# Altium schematic sheet JacdacHighPower 31.SchDoc
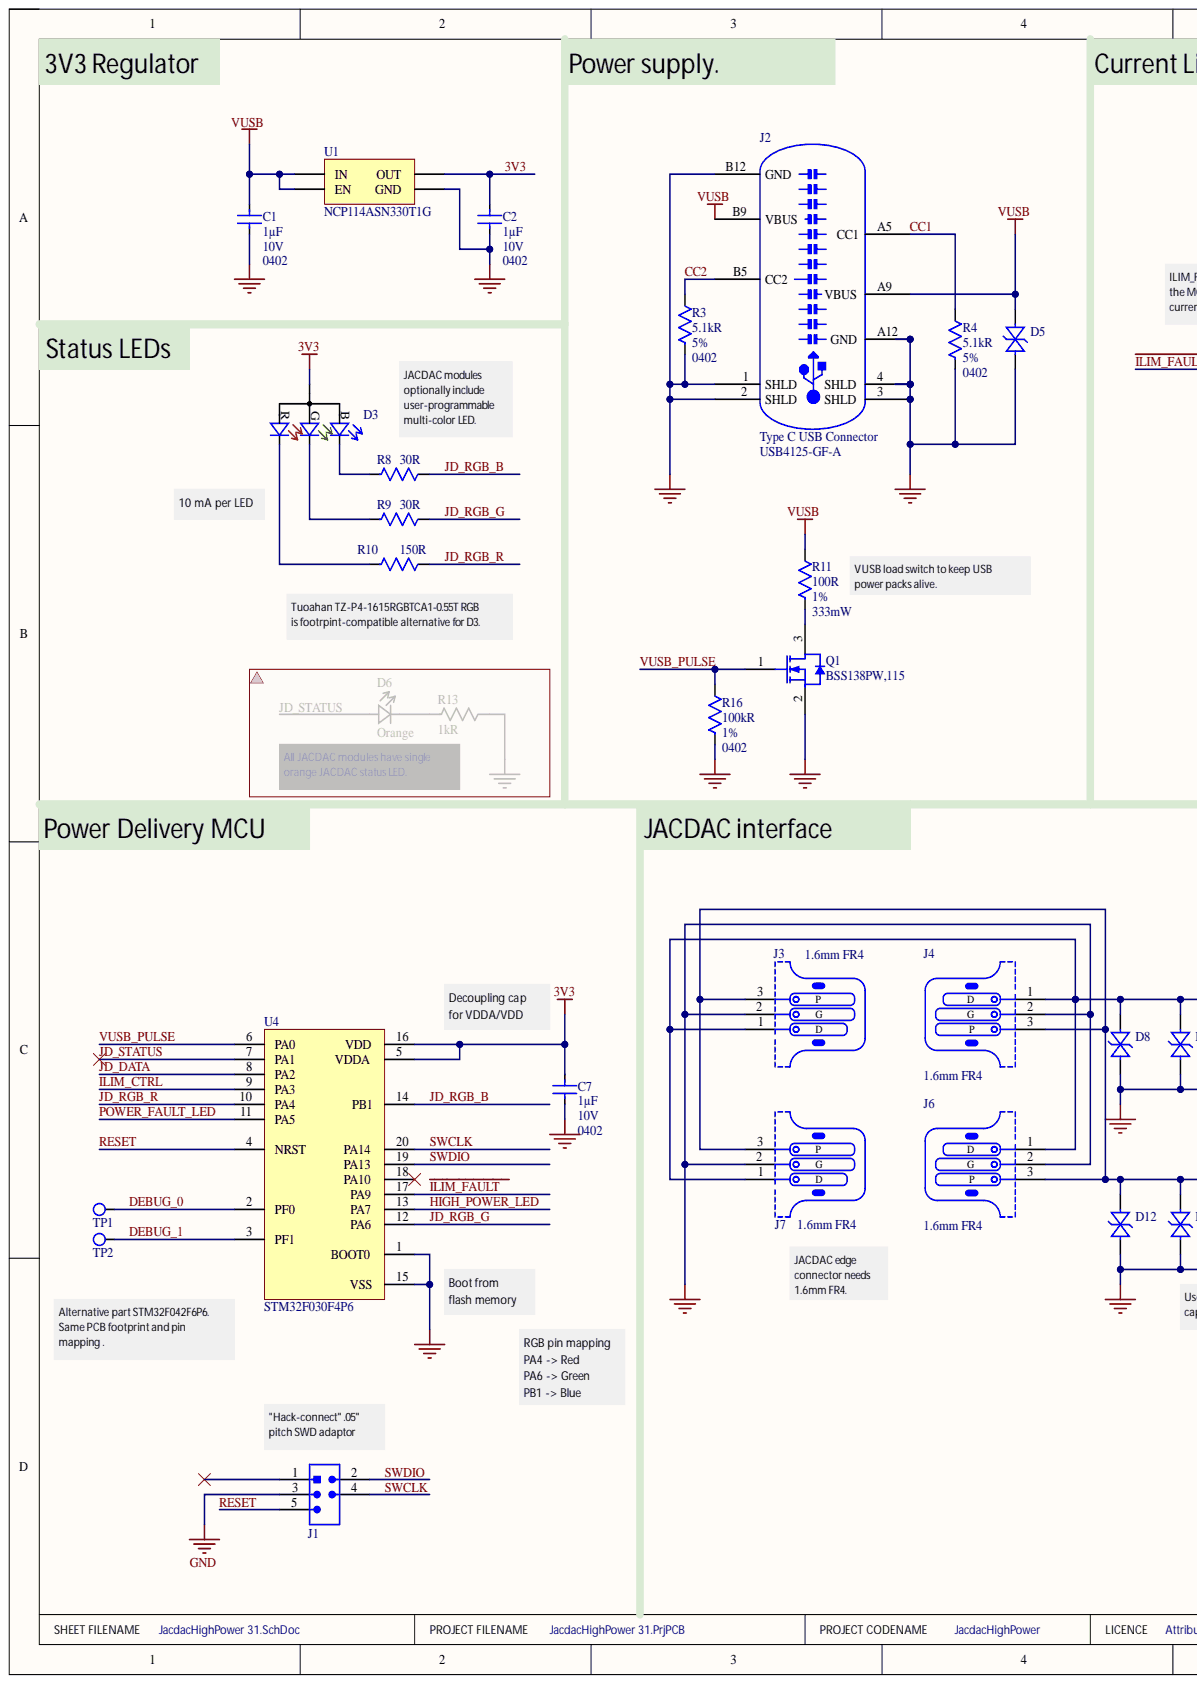
[diagram p0: JacdacHighPower 31.SchDoc, left]
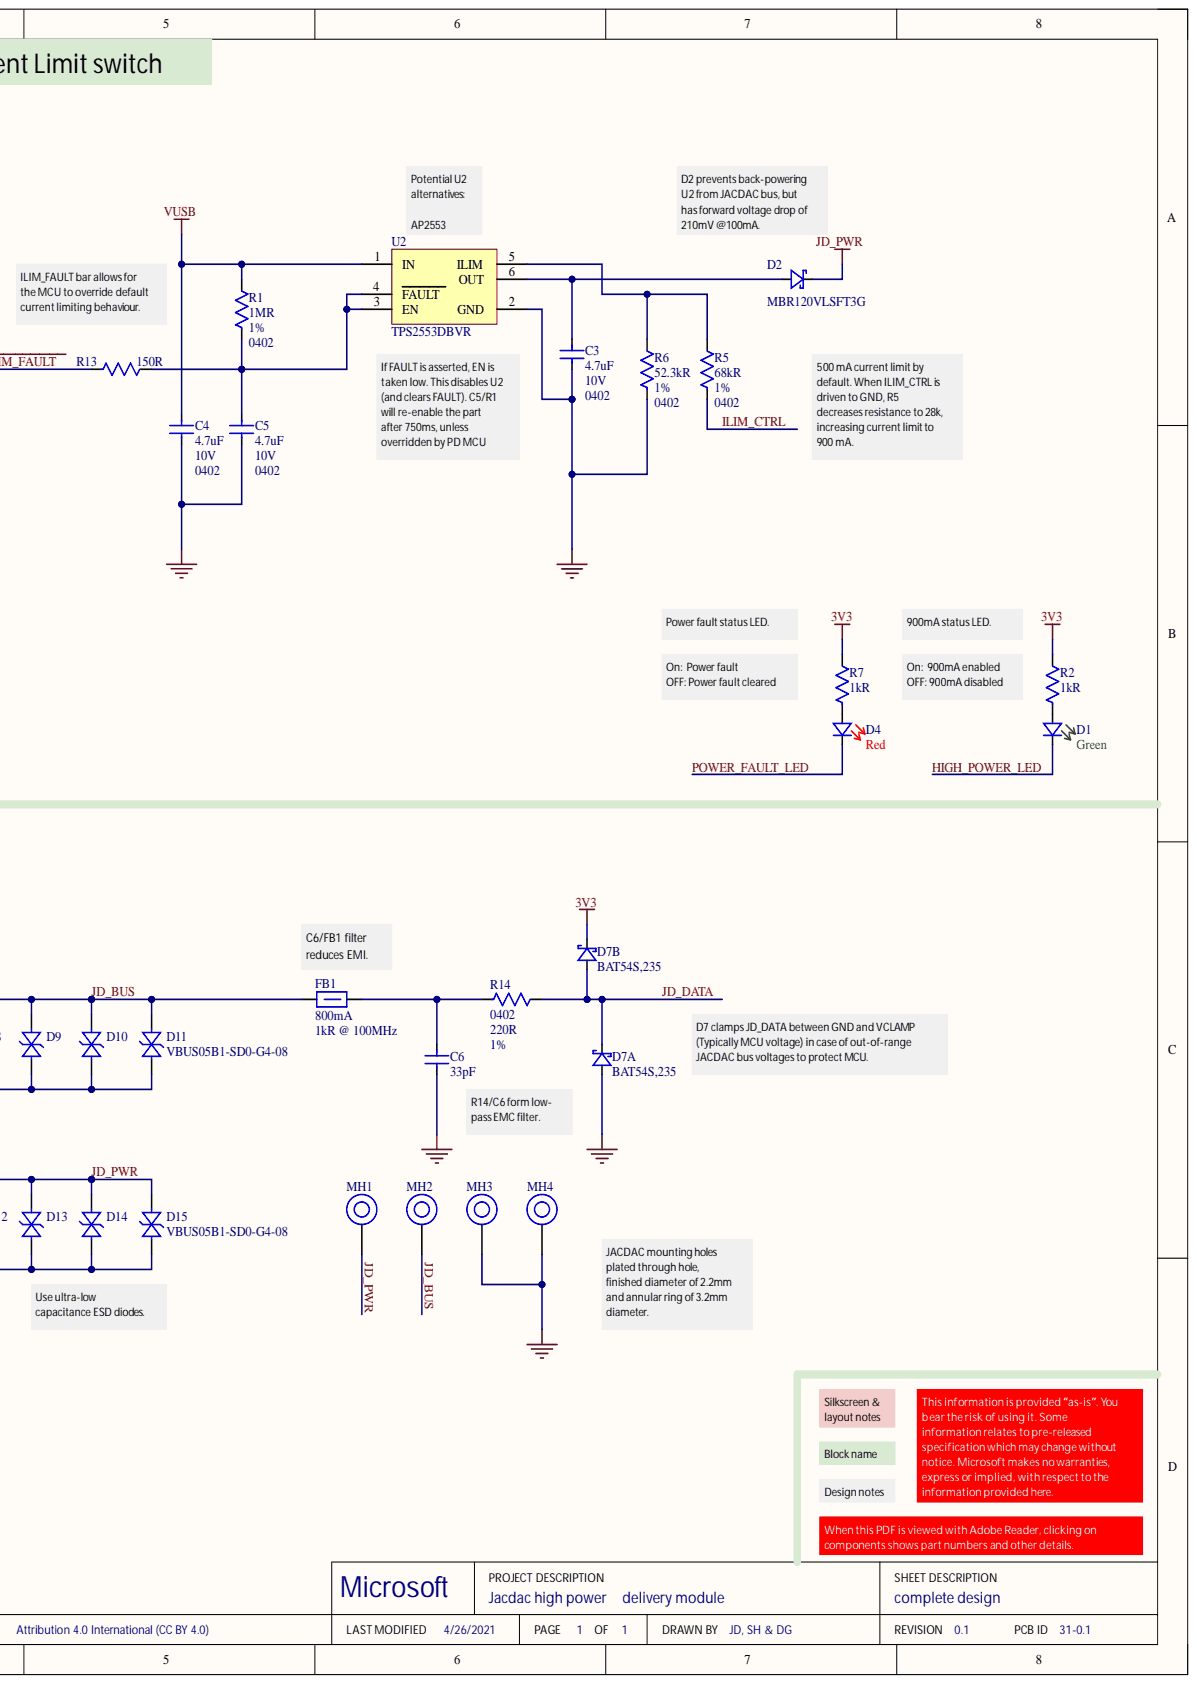
[diagram p1: JacdacHighPower 31.SchDoc, right]

## Parts
C1  Capacitance: 1µF  Case Code (Imperial): 0402  Voltage Rating: 10V
C2  Capacitance: 1µF  Case Code (Imperial): 0402  Voltage Rating: 10V
C3  Capacitance: 4.7uF  Case Code (Imperial): 0402  Voltage Rating: 10V
C4  Capacitance: 4.7uF  Case Code (Imperial): 0402  Voltage Rating: 10V
C5  Capacitance: 4.7uF  Case Code (Imperial): 0402  Voltage Rating: 10V
C6  Capacitance: 33pF
C7  Capacitance: 1µF  Case Code (Imperial): 0402  Voltage Rating: 10V
D1  Colour: Green
D2  Manufacturer Part Number: MBR120VLSFT3G
D3  (no value)
D4  Colour: Red
D5  (no value)
D7  Manufacturer Part Number: BAT54S,235  (parts A,B)
D8  (no value)
D9  (no value)
D10  (no value)
D11  Manufacturer Part Number: VBUS05B1-SD0-G4-08
D12  (no value)
D13  (no value)
D14  (no value)
D15  Manufacturer Part Number: VBUS05B1-SD0-G4-08
FB1  Current Rating: 800mA  Impedance: 1kR @ 100MHz
J1  (no value)
J2  Manufacturer Part Number: USB4125-GF-A
J3  PCB Type: 1.6mm FR4
J4  PCB Type: 1.6mm FR4
J6  PCB Type: 1.6mm FR4
J7  PCB Type: 1.6mm FR4
MH1  (no value)
MH2  (no value)
MH3  (no value)
MH4  (no value)
Q1  Manufacturer Part Number: BSS138PW,115
R1  Case Code (Imperial): 0402  Resistance: 1MR  Resistance Tolerance: 1%
R2  Resistance: 1kR
R3  Case Code (Imperial): 0402  Resistance: 5.1kR  Resistance Tolerance: 5%
R4  Case Code (Imperial): 0402  Resistance: 5.1kR  Resistance Tolerance: 5%
R5  Case Code (Imperial): 0402  Resistance: 68kR  Tolerance: 1%
R6  Case Code (Imperial): 0402  Resistance: 52.3kR  Tolerance: 1%
R7  Resistance: 1kR
R8  Resistance: 30R
R9  Resistance: 30R
R10  Resistance: 150R
R11  Max Power Dissipation: 333mW  Resistance: 100R  Tolerance: 1%
R13  Resistance: 150R
R14  Case Code (Imperial): 0402  Resistance: 220R  Tolerance: 1%
R16  Case Code (Imperial): 0402  Resistance: 100kR  Tolerance: 1%
TP1  (no value)
TP2  (no value)
U1  Manufacturer Part Number: NCP114ASN330T1G
U2  Manufacturer Part Number 1: TPS2553DBVR
U4  Manufacturer Part Number: STM32F030F4P6

## Nets
GND: C1.1 C2.1 C3.1 C4.1 C5.1 C6.1 C7.1 D5.2 D7.1 D8.2 D9.2 D10.2 D11.2 D12.2 D13.2 D14.2 D15.2 J1.3 J2.1(SHLD) J2.2(SHLD) J2.3(SHLD) J2.4(SHLD) J2.A12(GND) J2.B12(GND) J3.2 J4.2 J6.2 J7.2 MH3.1 MH4.1 Q1.2 R3.2 R4.2 R6.1 R16.1 U1.2(GND) U2.2(GND) U4.1(BOOT0) U4.15(VSS)
VUSB: C1.2 C4.2 D5.1 J2.A9(VBUS) J2.B9(VBUS) R1.1 R11.2 U1.1(IN) U1.3(EN) U2.1(IN)
3V3: C2.2 C7.2 D3.1 D7.2 R2.1 R7.1 U1.5(OUT) U4.5(VDDA) U4.16(VDD)
~{ILIM_FAULT}: R13.1 U4.17(PA9)
CC1: J2.A5(CC1) R4.1
CC2: J2.B5(CC2) R3.1
DEBUG_0: TP1.1 U4.2(PF0)
DEBUG_1: TP2.1 U4.3(PF1)
HIGH_POWER_LED: D1.1 U4.13(PA7)
ILIM_CTRL: R5.1 U4.9(PA3)
JD_BUS: D8.1 D9.1 D10.1 D11.1 FB1.1 J3.1 J4.1 J6.1 J7.1 MH2.1
JD_DATA: D7.3 R14.1 U4.8(PA2)
JD_PWR: D2.1 D12.1 D13.1 D14.1 D15.1 J3.3 J4.3 J6.3 J7.3 MH1.1
JD_RGB_B: R8.2 U4.14(PB1)
JD_RGB_G: R9.2 U4.12(PA6)
JD_RGB_R: R10.2 U4.10(PA4)
JD_STATUS: U4.7(PA1)
POWER_FAULT_LED: D4.1 U4.11(PA5)
RESET: J1.5 U4.4(NRST)
SWCLK: J1.4 U4.20(PA14)
SWDIO: J1.2 U4.19(PA13)
VUSB_PULSE: Q1.1 R16.2 U4.6(PA0)
NetC3_2: C3.2 D2.2 U2.6(OUT)
NetC5_2: C5.2 R1.2 R13.2 U2.3(EN) U2.4(FAULT)
NetC6_2: C6.2 FB1.2 R14.2
NetD1_2: D1.2 R2.2
NetD3_2: D3.2 R10.1
NetD3_3: D3.3 R9.1
NetD3_4: D3.4 R8.1
NetD4_2: D4.2 R7.2
NetQ1_3: Q1.3 R11.1
NetR5_2: R5.2 R6.2 U2.5(ILIM)

Not connected: J1 1; U4 18(PA10)

## Library data of the parts
C1 C2 C7 = (no symbol name): CAP CER 1UF 10V X5R 0402; Manufacturer: Samsung; Manufacturer Part Number: CL05A105KP5NNNC; Material: Ceramic; Tolerance: 10%; Case Code (Metric): 1005; Case/Package: 0402; Category: Capacitors; ComponentLink1Description: Online datasheet; ComponentLink1URL: https://datasheet.ciiva.com/15195/getdatasheetpartid-358390-15195630.pdf; Contact Plating: Tin; Dielectric: X5R; Max Operating Temperature: 85°C; Min Operating Temperature: -55°C; Supplier 1: Digi-Key; Supplier 2: Mouser; Supplier 3: Farnell; Supplier Part Number 1: 1276-1076-1-ND; Supplier Part Number 2: 187-CL05A105KP5NNNC; Supplier Part Number 3: 3013329
C3-C5 = (no symbol name): CAP CER 4.7UF 10V X5R 0402; Manufacturer: Samsung; Manufacturer Part Number: CL05A475MP5NRNC; Tolerance: 20%; Case Code (Metric): 1005; Case/Package: 0402; Category: Ceramic Capacitors; ComponentLink1Description: Online datasheet; ComponentLink1URL: https://datasheet.ciiva.com/25786/cl05a475mp5nrnc-25786963.pdf; Dielectric: X5R; Max Operating Temperature: 85°C; Min Operating Temperature: -55°C; RoHS Compliant: Yes; Series: CL; Supplier 1: Digi-Key; Supplier 2: Future Electronics; Supplier Part Number 1: 1276-1482-2-ND; Supplier Part Number 2: 2103694
C6 = (no symbol name): CAP CER 33PF 50V NP0 0402; Manufacturer: Samsung; Manufacturer Part Number: CL05C330JB51PNC; Tolerance: 5%; Case Code (Imperial): 0402; Case Code (Metric): 1005; Case/Package: 0402; Category: Capacitors; ComponentLink1Description: Samsung datasheet online; ComponentLink1URL: http://www.samsungsem.com/kr/support/library/product-catalog/mlcc.jsp; Max Operating Temperature: 125°C; Min Operating Temperature: -55°C; Ratings: AEC-Q200; Supplier 1: Digi-Key; Supplier 2: Mouser; Supplier 3: Future Electronics; Supplier Part Number 1: 1276-6515-1-ND; Supplier Part Number 2: 187-CL05C330JB51PNC; Supplier Part Number 3: 6022520; Voltage Rating: 50V
D1 = (no symbol name): 1.6 x 0.8 x 0.55mm (0603) LED 560nm Green; Manufacturer: Rohm Semiconductor; Manufacturer Part Number: SML-D12P8WT86; Current - Test: 20mA; Lens Style/Size: Rectangle with Flat Top, 1.2mm x 0.8mm; Lens Type: Diffused, White; Millicandela Rating: 6.3mcd; Voltage - Forward (Vf) (Typ): 2.2V; Category: Optoelectronics; ComponentLink1Description: Online datasheet; ComponentLink1URL: https://d1d2qsbl8m0m72.cloudfront.net/en/products/databook/datasheet/opto/led/chip_mono/sml-d12x8_d13x8-e.pdf; Luminous Flux @ Current - Test: -; Package / Case: 0603 (1608 Metric); Size / Dimension: 1.60mm L x 0.80mm W; Supplier 1: Digi-Key; Supplier Part Number 1: 511-1579-1-ND; Viewing Angle: -; Wavelength - Dominant: 560nm; Wavelength - Peak: -
D2 = (no symbol name): DIODE SCHOTTKY 20V 1A SOD123FL; Manufacturer: ON Semiconductor; Element Configuration: Single; Forward Voltage: 340mV; Max Forward Surge Current (Ifsm): 45A; Max Reverse Leakage Current: 600uA; Polarity: Standard; Average Rectified Current: 1A; Category: Schottky Diodes; ComponentLink4Description: On Semi datasheet online; ComponentLink4URL: https://datasheet.ciiva.com/6380/mbr120vlsft1-d-6380910.pdf; Current Rating: 1A; Forward Current: 1A; Halogen Free: Halogen Free; Max Operating Temperature: 125°C; Max Repetitive Reverse Voltage (Vrrm): 20V; Max Reverse Voltage (DC): 20V; Max Surge Current: 45A; Min Operating Temperature: -65°C; Number of Pins: 2; Output Current: 1A; Peak Non-Repetitive Surge Current: 45A; Peak Reverse Current: 600uA; Supplier 1: Digi-Key; Supplier 2: Mouser; Supplier 3: RSComponents; Supplier Part Number 1: MBR120VLSFT3GOSCT-ND; Supplier Part Number 2: 863-MBR120VLSFT3G; Supplier Part Number 3: 1867479; Voltage Rating (DC): 20V
D3 = (no symbol name): Red, Green, Blue (RGB) 626nm Red, 525nm Green, 470nm Blue LED Indication - Discrete 1.9V Red, 3.4V Green, 3.4V Blue 0606 (1616 Metric); Manufacturer: Broadcom Avago; Manufacturer Part Number: HSMF-C114; Color: Blue,Green,Red; Forward Current: 20mA; Lens Color: White; Lens Style: Diffused,Rectangular; Lens Transparency: Diffused; Luminous Intensity: 70mcd; Number of Elements: 3; Power Rating: 78mW; Reverse Voltage: 5V; Viewing Angle: 145°; Case Code (Imperial): 0606; Case Code (Metric): 1616; Case/Package: 0606; Category: Optoelectronics; ComponentLink1Description: Online datasheet; ComponentLink1URL: https://docs.broadcom.com/docs/AV02-2451EN; Dominant Wavelength: 470nm; Forward Voltage: 3.4V; Max Operating Temperature: 85°C; Min Operating Temperature: -40°C; Number of LEDs: 3; Number of Pins: 4; Supplier 1: Digi-Key; Supplier 2: Farnell; Supplier 3: Mouser; Supplier Part Number 1: 516-1795-1-ND; Supplier Part Number 2: 1058362; Supplier Part Number 3: 630-HSMF-C114; Test Current: 20mA; Wavelength: 470nm
D4 = (no symbol name): 1.6 x 0.8 x 0.55mm (0603) LED 620nm Red; Manufacturer: Rohm Semiconductor; Manufacturer Part Number: SML-D12U8WT86; Current - Test: 20mA; Lens Style/Size: Rectangle with Flat Top, 1.2mm x 0.8mm; Lens Type: Diffused, White; Millicandela Rating: 63mcd; Voltage - Forward (Vf) (Typ): 2.2V; Category: Optoelectronics; ComponentLink1Description: Online datasheet; ComponentLink1URL: https://d1d2qsbl8m0m72.cloudfront.net/en/products/databook/datasheet/opto/led/chip_mono/sml-d12x8_d13x8-e.pdf; Luminous Flux @ Current - Test: -; Package / Case: 0603 (1608 Metric); Size / Dimension: 1.60mm L x 0.80mm W; Supplier 1: Digi-Key; Supplier 2: Farnell; Supplier Part Number 1: 511-1580-1-ND; Supplier Part Number 2: 1685094; Viewing Angle: -; Wavelength - Dominant: 620nm; Wavelength - Peak: -
D5 D8-D10 D12-D14 = (no symbol name): TVS DIODE 5.5V 18V CLP0603; Manufacturer: Vishay Semiconductors; Manufacturer Part Number: VBUS05B1-SD0-G4-08; Capacitance: 0.4pF; Direction: Bidirectional; Element Configuration: Single; Min Breakdown Voltage: 6V; Number of Bidirectional Channels: 1; Peak Pulse Current: 2.5A; Peak Pulse Power: 45W; Power Line Protection: No; Case Code (Imperial): 0201; Case Code (Metric): 0603; Case/Package: 0201; Category: TVS Diodes; Clamping Voltage: 18V; ComponentLink2Description: Online datasheet; ComponentLink2URL: http://www.vishay.com/docs/85899/vbus05b1-sd0.pdf; Max Operating Temperature: 150°C; Min Operating Temperature: -55°C; Number of Pins: 2; Resistor Type: Zener; Reverse Standoff Voltage: 5.5V; Supplier 1: Digi-Key; Supplier 2: Farnell; Supplier 3: Mouser; Supplier 4: Arrow Electronics; Supplier Part Number 1: VBUS05B1-SD0-G4-08GICT-ND; Supplier Part Number 2: 2932858; Supplier Part Number 3: 78-VBUS05B1SD0-G4-08; Supplier Part Number 4: VBUS05B1-SD0-G4-08; Working Voltage: 5.5V
D7 = (no symbol name): DIODE ARRAY SCHOTTKY 30V SOT23; Manufacturer: Nexperia; Category: Rectifier Diodes; ComponentLink1Description: NXP datasheet online; ComponentLink1URL: https://assets.nexperia.com/documents/data-sheet/BAT54_SER.pdf; Supplier 1: Digi-Key; Supplier 2: Mouser; Supplier 3: RSComponents; Supplier Part Number 1: 1727-1868-1-ND; Supplier Part Number 2: 771-BAT54S235; Supplier Part Number 3: 1707990
D11 D15 = (no symbol name): TVS DIODE 5.5V 18V CLP0603; Manufacturer: Vishay Semiconductors; Capacitance: 0.4pF; Direction: Bidirectional; Element Configuration: Single; Min Breakdown Voltage: 6V; Number of Bidirectional Channels: 1; Peak Pulse Current: 2.5A; Peak Pulse Power: 45W; Power Line Protection: No; Case Code (Imperial): 0201; Case Code (Metric): 0603; Case/Package: 0201; Category: TVS Diodes; Clamping Voltage: 18V; ComponentLink2Description: Online datasheet; ComponentLink2URL: http://www.vishay.com/docs/85899/vbus05b1-sd0.pdf; Max Operating Temperature: 150°C; Min Operating Temperature: -55°C; Number of Pins: 2; Resistor Type: Zener; Reverse Standoff Voltage: 5.5V; Supplier 1: Digi-Key; Supplier 2: Farnell; Supplier 3: Mouser; Supplier 4: Arrow Electronics; Supplier Part Number 1: VBUS05B1-SD0-G4-08GICT-ND; Supplier Part Number 2: 2932858; Supplier Part Number 3: 78-VBUS05B1SD0-G4-08; Supplier Part Number 4: VBUS05B1-SD0-G4-08; Working Voltage: 5.5V
FB1 = (no symbol name): 0603 1k@100MHz 0.8A chip; Manufacturer: TDK; Manufacturer Part Number: MPZ1608S102ATA00; Core Material: Ferrite; DC Resistance (DCR): 300mR; Tolerance: 25%; Case Code (Imperial): 0603; Case Code (Metric): 1608; Case/Package: 0603; ComponentLink2Description: datasheet online; ComponentLink2URL: https://www.mouser.co.uk/datasheet/2/400/eads_commercial_power_mpz1608_en-923650.pdf; ComponentLink3Description: datasheet; Max DC Current: 800mA; Supplier 1: Digi-Key; Supplier 2: Mouser; Supplier Part Number 1: 445-8694-1-ND; Supplier Part Number 2: 810-MPZ1608S102ATA00; ComponentLink3URL: Y:\System\Altium\Libraries 2014\TDK\Datasheets\eads_commercial_power_mpz1608_en-923650.pdf
J1 = (no symbol name): Hack Connect Mini 1.27 mm pitch 2-row
J2 = (no symbol name): USB-C (USB TYPE-C) USB 2.0 Receptacle Connector 24 (6+18 Dummy) Position Surface Mount, Right Angle; Through Hole; Manufacturer: GCT; Manufacturer 1: Global Connector Technology; ComponentLink1Description: Datasheet online; ComponentLink1URL: https://gct.co/files/drawings/usb4125.pdf; ComponentLink2Description: Datasheet offline; ComponentLink3Description: Digi-Key order link; ComponentLink3URL: https://www.digikey.co.uk/product-detail/en/gct/USB4125-GF-A/2073-USB4125-GF-ATR-ND/13547388; Manufacturer Part Number 1: USB4125-GF-A; Supplier 1: Digi-Key; Supplier 2: Farnell; Supplier Part Number 1: 2073-USB4125-GF-ACT-ND; Supplier Part Number 2: 3595674; ComponentLink2URL: Y:\System\Altium\Libraries 2014\GCT\Datasheets\usb4125.pdf
J3 J4 J6 J7 = (no symbol name): 3 pin jacdac edge connector 1.27mm pitch; Manufacturer Part Number: JACDAC_EDGE_CONNECTOR; ComponentLink1Description: JACDAC PCB edge connector spec onlin; ComponentLink1URL: https://github.com/microsoft/jacdac-mdk/blob/main/connector/JACDAC_PCB_Edge_Connector_Drawing_JD-PEC-01_rev_01.pdf; ComponentLink2Description: Yuliang JACDAC cable connector drawing online; ComponentLink2URL: https://github.com/microsoft/jacdac-mdk/blob/main/connector/JACDAC-Connector-by-Yuliang-2020-11-28.pdf
MH1-MH4 = (no symbol name): Mounting Hole 5.0mm keepout, 3.0mm annualar ring and 2.1mm Drill PTH
Q1 = (no symbol name): NEXPERIA - BSS138PW,115 - N CHANNEL MOSFET, TRENCH, 60V, 320MA, SOT-323, FULL REEL; Category: Semiconductor; ComponentLink3Description: Online datasheet; ComponentLink3URL: https://assets.nexperia.com/documents/data-sheet/BSS138PW.pdf; Manufacturer: Nexperia; Supplier 1: Digi-Key; Supplier 2: Mouser; Supplier 3: Farnell; Supplier Part Number 1: 1727-1143-6-ND; Supplier Part Number 2: 771-BSS138PW115; Supplier Part Number 3: 1907611
R1 = (no symbol name): RES SMD 1M OHM 1% 1/16W 0402; Manufacturer: Yageo; Manufacturer Part Number: RC0402FR-071ML; Max Power Dissipation: 63mW; Temperature Coefficient: 100ppm/°C; Voltage Rating: 50V; Case Code (Metric): 1005; Case/Package: 0402; Category: Chip SMD Resistors; ComponentLink1Description: Online datasheet; ComponentLink1URL: https://datasheet.ciiva.com/11808/0900766b80c66297-11808125.pdf; Construction: Rectangular; Features: Moisture Resistant; Max Operating Temperature: 155°C; Min Operating Temperature: -55°C; Number of Terminations: 2; Power Rating: 63mW; Resistor Type: Thick Film; RoHS Compliant: Yes; Series: RC; Size Code: 0402; Supplier 1: Digi-Key; Supplier 2: Mouser; Supplier 3: Farnell; Supplier Part Number 1: 311-1.00MLRCT-ND; Supplier Part Number 2: 603-RC0402FR-071ML; Supplier Part Number 3: 2421892; Technology: THICK FILM; Termination: SMD/SMT; Tolerance: 1%
R2 R7 = (no symbol name): RES SMD 1K OHM 1% 1/16W 0402; Manufacturer: Yageo; Manufacturer Part Number: RC0402FR-071KL; Power Rating: 62.5mW; Temperature Coefficient: 100ppm/°C; Voltage Rating: 50V; Case Code (Imperial): 0402; Case Code (Metric): 1005; Case/Package: 0402; Category: Resistors; ComponentLink2Description: Online datasheet; ComponentLink2URL: https://datasheet.ciiva.com/12666/pyu-rc0402-51-rohs-l-6-r-12666741.pdf; Max Operating Temperature: 155°C; Min Operating Temperature: -55°C; Number of Pins: 2; Resistor Type: Thick Film; Supplier 1: Digi-Key; Supplier 2: Mouser; Supplier 3: Farnell; Supplier Part Number 1: 311-1.00KLRDKR-ND; Supplier Part Number 2: 603-RC0402FR-071KL; Supplier Part Number 3: 2132441; Termination: SMD/SMT; Tolerance: 1%; Voltage Rating (DC): 50V
R3 R4 = (no symbol name): RES SMD 5.1K OHM 5% 1/16W 0402; Manufacturer: Yageo; Manufacturer Part Number: RC0402JR-075K1L; Max Power Dissipation: 63mW; Power Rating: 62.5mW; Temperature Coefficient: 100ppm/°C; Voltage Rating: 50V; Case Code (Metric): 1005; Case/Package: 0402; Category: Chip SMD Resistors; ComponentLink2Description: Onnline datasheet; ComponentLink2URL: https://datasheet.ciiva.com/16740/pyu-rc0402-51-rohs-l-6-r-16740925.pdf; Construction: Rectangular; Features: Moisture Resistant; Max Operating Temperature: 155°C; Min Operating Temperature: -55°C; Number of Terminations: 2; Resistor Type: Thick Film; RoHS Compliant: Yes; Series: RC; Size Code: 0402; Supplier 1: Digi-Key; Supplier 2: Newark; Supplier 3: Mouser; Supplier 4: Farnell; Supplier 5: Farnell; Supplier Part Number 1: 311-5.1KJRCT-ND; Supplier Part Number 2: 68R0016; Supplier Part Number 3: 603-RC0402JR-075K1L; Supplier Part Number 4: 3495714; Supplier Part Number 5: 1799293; Termination: SMD/SMT; Tolerance: 5%; Voltage Rating (DC): 50V; Weight: 2.3E-05oz
R5 = (no symbol name): RES SMD 68K OHM 1% 1/16W 0402; Manufacturer: Yageo; Manufacturer Part Number: RT0402FRE0768KL; Max Power Dissipation: 63mW; Power Rating: 62.5mW; Temperature Coefficient: 50ppm/°C; Voltage Rating: 50V; Case Code (Metric): 1005; Case/Package: 0402; Category: Chip SMD Resistors; ComponentLink1Description: Online datasheet; ComponentLink1URL: https://datasheet.ciiva.com/2561/pyu-rt-1-to-0-05-rohs-l-5-2561824.pdf?src-supplier=Digi-Key; Contact Plating: Tin,Matte; Max Operating Temperature: 125°C; Min Operating Temperature: -55°C; Number of Terminations: 2; Resistor Type: Thin Film; RoHS Compliant: Yes; Series: RT; Supplier 1: Digi-Key; Supplier 2: Mouser; Supplier Part Number 1: 13-RT0402FRE0768KLCT-ND; Supplier Part Number 2: 603-RT0402FRE0768KL; Termination: SMD/SMT; Voltage Rating (DC): 50V
R6 = (no symbol name): RES SMD 52.3K OHM 1% 1/16W 0402; Manufacturer: Yageo; Manufacturer Part Number: RC0402FR-0752K3L; Max Power Dissipation: 63mW; Power Rating: 62.5mW; Temperature Coefficient: 100ppm/°C; Voltage Rating: 50V; Case Code (Metric): 1005; Case/Package: 0402; Category: Chip SMD Resistors; ComponentLink1Description: Oline datasheet; ComponentLink1URL: https://datasheet.ciiva.com/16867/pyu-rc0402-51-rohs-l-6-16867930.pdf; Construction: Rectangular; Contact Plating: Tin,Matte; Features: Moisture Resistant; Max Operating Temperature: 155°C; Min Operating Temperature: -55°C; Number of Terminations: 2; Resistor Type: Thick Film; RoHS Compliant: Yes; Series: RC; Size Code: 0402; Supplier 1: Digi-Key; Supplier 2: Mouser; Supplier Part Number 1: YAG3179CT-ND; Supplier Part Number 2: 603-RC0402FR-0752K3L; Termination: SMD/SMT; Voltage Rating (DC): 50V; Weight: 2.3E-05oz
R8 R9 = (no symbol name): RES SMD 30 OHM 1% 1/16W 0402; Manufacturer: Yageo; Manufacturer Part Number: RC0402FR-0730RL; Max Power Dissipation: 63mW; Temperature Coefficient: 100ppm/°C; Voltage Rating: 50V; Case Code (Imperial): 0402; Case Code (Metric): 1005; Case/Package: 0402; Category: Chip SMD Resistors; ComponentLink1Description: Online datasheet; ComponentLink1URL: https://datasheet.ciiva.com/7849/1018594-7849958.pdf; Construction: Rectangular; Contact Plating: Tin,Matte; Features: Moisture Resistant; Max Operating Temperature: 155°C; Min Operating Temperature: -55°C; Number of Terminations: 2; Power Rating: 63mW; Resistance Tolerance: 1%; Resistor Type: Thick Film; RoHS Compliant: Yes; Series: RC; Size Code: 0402; Supplier 1: Digi-Key; Supplier 2: Mouser; Supplier 3: Farnell; Supplier Part Number 1: 311-30LRCT-ND; Supplier Part Number 2: 603-RC0402FR-0730RL; Supplier Part Number 3: 1458775; Termination: SMD/SMT; Tolerance: 1%; Voltage Rating (DC): 50V
R10 R13 = (no symbol name): RES SMD 150 OHM 1% 1/16W 0402; Manufacturer: Yageo; Manufacturer Part Number: RC0402FR-07150RL; Max Power Dissipation: 63mW; Power Rating: 62.5mW; Temperature Coefficient: 100ppm/°C; Voltage Rating: 50V; Case Code (Imperial): 0402; Case Code (Metric): 1005; Case/Package: 0402; Category: Chip SMD Resistors; ComponentLink1Description: ine datasheet; ComponentLink1URL: https://datasheet.ciiva.com/11808/0900766b80c66297-11808422.pdf; Construction: Rectangular; Features: Moisture Resistant; Max Operating Temperature: 155°C; Min Operating Temperature: -55°C; Number of Terminations: 2; Resistance Tolerance: 1%; Resistor Type: Thick Film; RoHS Compliant: Yes; Series: RC; Supplier 1: Digi-Key; Supplier 2: Mouser; Supplier 3: Farnell; Supplier Part Number 1: 311-150LRCT-ND; Supplier Part Number 2: 603-RC0402FR-07150RL; Supplier Part Number 3: 2421893; Technology: THICK FILM; Termination: SMD/SMT; Tolerance: 1%; Working Voltage: 50V
R11 = (no symbol name): 100 Ohms ±1% 0.333W, 1/3W Chip Resistor 0805 (2012 Metric) Pulse Withstanding Thick Film; Manufacturer: TE Connectivity; Manufacturer Part Number: CRGP0805F100R; Temperature Coefficient: 200ppm/°C; Case Code (Imperial): 0805; Case Code (Metric): 2012; Case/Package: 0805; Category: Chip SMD Resistors; ComponentLink1Description: Online datasheet; ComponentLink1URL: https://www.te.com/commerce/DocumentDelivery/DDEController?Action=showdoc&DocId=Data+Sheet%7F9-1773463-9%7FA%7Fpdf%7FEnglish%7FENG_DS_9-1773463-9_A.pdf%7F1-2176327-3; Max Operating Temperature: 155°C; Min Operating Temperature: -55°C; Number of Terminations: 2; Resistor Type: Thick Film; RoHS Compliant: Yes; Supplier 1: Digi-Key; Supplier 2: Mouser; Supplier 3: Farnell; Supplier Part Number 1: A130465CT-ND; Supplier Part Number 2: 279-CRGP0805F100R; Supplier Part Number 3: 2861083
R14 = (no symbol name): RES SMD 220 OHM 1% 1/16W 0402; Manufacturer: Yageo; Manufacturer Part Number: RC0402FR-07220RL; Max Power Dissipation: 63mW; Power Rating: 62.5mW; Temperature Coefficient: 100ppm/°C; Voltage Rating: 50V; Case Code (Metric): 1005; Case/Package: 0402; Category: Resistors; ComponentLink1Description: https://datasheet.ciiva.com/11819/0900766b80c66297-11819466.pdf?src-supplier=RSComponents; ComponentLink1URL: https://datasheet.ciiva.com/11819/0900766b80c66297-11819466.pdf?src-supplier=RSComponents; ComponentLink2Description: https://datasheet.ciiva.com/2453/pyu-r-int-thick-7-2453460.pdf?src-supplier=Digi-Key; ComponentLink2URL: https://datasheet.ciiva.com/2453/pyu-r-int-thick-7-2453460.pdf?src-supplier=Digi-Key; ComponentLink3Description: https://datasheet.ciiva.com/2453/pyu-r-int-thick-7-2453461.pdf?src-supplier=Digi-Key; ComponentLink3URL: https://datasheet.ciiva.com/2453/pyu-r-int-thick-7-2453461.pdf?src-supplier=Digi-Key; ComponentLink4Description: https://datasheet.ciiva.com/2453/pyu-r-int-thick-7-2453462.pdf?src-supplier=Digi-Key; ComponentLink4URL: https://datasheet.ciiva.com/2453/pyu-r-int-thick-7-2453462.pdf?src-supplier=Digi-Key; ComponentLink5Description: https://datasheet.ciiva.com/16746/pyu-rc0402-51-rohs-l-6-r-16746831.pdf?src-supplier=Future+Electronics; ComponentLink5URL: https://datasheet.ciiva.com/16746/pyu-rc0402-51-rohs-l-6-r-16746831.pdf?src-supplier=Future+Electronics; Features: Moisture Resistant; Max Operating Temperature: 155°C; Min Operating Temperature: -55°C; Number of Pins: 2; Number of Terminations: 2; Resistor Type: Thick Film; Supplier 1: Digi-Key; Supplier 2: Mouser; Supplier 3: Farnell; Supplier Part Number 1: 311-220LRCT-ND; Supplier Part Number 2: 603-RC0402FR-07220RL; Supplier Part Number 3: 2147864; Termination: SMD/SMT; Voltage Rating (DC): 50V
R16 = (no symbol name): RES SMD 100K OHM 1% 1/16W 0402; Manufacturer: Yageo; Manufacturer Part Number: RC0402FR-07100KL; Max Power Dissipation: 63mW; Temperature Coefficient: 100ppm/°C; Voltage Rating: 50V; Case Code (Metric): 1005; Case/Package: 0402; Category: Resistors; ComponentLink2Description: Online datasheet; ComponentLink2URL: https://datasheet.ciiva.com/2453/pyu-r-int-thick-7-2453361.pdf; Features: Moisture Resistant; Max Operating Temperature: 155°C; Min Operating Temperature: -55°C; Number of Terminations: 2; Power Rating: 63mW; Resistor Type: Thick Film; Supplier 1: Digi-Key; Supplier 2: Mouser; Supplier 3: Farnell; Supplier Part Number 1: 311-100KLRCT-ND; Supplier Part Number 2: 603-RC0402FR-07100KL; Supplier Part Number 3: 2131798; Termination: SMD/SMT; Working Voltage: 50V
TP1 TP2 = (no symbol name): Test Point
U1 = (no symbol name): ON SEMICONDUCTOR - NCP114ASN330T1G - LDO Voltage Regulator, Fixed, 1.7 V to 5.5 V in, 3.3 V/300 mA out, 150 mV drop, TSOP-5; Dropout Voltage: 225mV; Max Input Voltage: 5.5V; Min Output Voltage: 3.3V; Number of Regulators: 1; Output Configuration: Positive; Output Current: 300mA; Power Supply Rejection Ratio (PSRR): 75dB; Quiescent Current: 95uA; Case/Package: SOT-23-5; Category: Voltage Regulators - Linear; ComponentLink3Description: On Semi datasheet online; ComponentLink3URL: http://www.onsemi.com/pub/Collateral/NCP114-D.PDF; Manufacturer: ON Semiconductor; Max Operating Temperature: 85°C; Min Operating Temperature: -40°C; Output Type: Fixed; Supplier 1: Digi-Key; Supplier 2: RSComponents; Supplier 3: Mouser; Supplier Part Number 1: NCP114ASN330T1GOSCT-ND; Supplier Part Number 2: 1263178; Supplier Part Number 3: 863-NCP114ASN330T1G
U2 = (no symbol name): POWER LOAD SWITCH, HIGH SIDE, SOT-23-6; Power Load Switch Type:High Side; Input Voltage:6.5V; Current Limit:1.7A; On State Resistance:0.085ohm; Distribution Switch Case Style:SOT-23; No. of Pins:6; Thermal Protection:Yes; On / Enable;RoHS Compliant: Yes; Manufacturer 1: Texas Instruments; Max Input Voltage: 6.5V; Max Power Dissipation: 625mW; Min Input Voltage: 2.5V; Number of Outputs: 1; On-State Resistance: 85mR; Output Current: 1.5A; Case/Package: SOT-23; Category: Hot Swap Controllers; ComponentLink1Description: https://datasheet.ciiva.com/15108/getdatasheetpartid-297517-15108509.pdf?src-supplier=Verical; ComponentLink1URL: https://datasheet.ciiva.com/15108/getdatasheetpartid-297517-15108509.pdf?src-supplier=Verical; Max Operating Temperature: 85°C; Max Supply Voltage: 6.5V; Min Operating Temperature: -40°C; Min Supply Voltage: 2.5V; Number of Pins: 6; Supplier 1: Digi-Key; Supplier 2: Farnell; Supplier 3: Mouser; Supplier Part Number 1: 296-32464-1-ND; Supplier Part Number 2: 3124082; Supplier Part Number 3: 595-TPS2553DBVR; Weight: 0.00127oz
U4 = (no symbol name): STMICROELECTRONICS - STM32F030F4P6 - 32 Bit Microcontroller, Value Line, ARM Cortex-M0, 48 MHz, 16 KB, 4 KB, 20, TSSOP RoHS Compliant: Yes; Categories: Integrated Circuits; Data Bus Width: 32b; Frequency: 48MHz; Interface: I2C,SPI,UART,USART; Max Power Dissipation: 182mW; Max Supply Voltage: 3.6V; Memory Size: 16KB; Memory Type: FLASH; Min Supply Voltage: 2.4V; Number of ADC Channels: 16; Number of I2C Channels: 1; Number of I/Os: 15; Number of PWM Channels: 6; Number of Timers/Counters: 5; Oscillator Type: Internal; Peripherals: POR,PWM,WDT,DMA; RAM Size: 4KB; Case/Package: TSSOP; Category: Microcontrollers; ComponentLink1Description: Online datasheet; ComponentLink1URL: https://datasheet.ciiva.com/29720/dm00088500-29720640.pdf; Contact Plating: Gold; Core Architecture: ARM; Manufacturer: STMicroelectronics; Max Frequency: 48MHz; Max Operating Temperature: 85°C; Min Operating Temperature: -40°C; Number of Pins: 20; Number of Programmable I/O: 15; Number of SPI Channels: 1; Supplier 1: Farnell; Supplier 2: Digi-Key; Supplier 3: RSComponents; Supplier Part Number 1: 2393635; Supplier Part Number 2: 497-14044-5-ND; Supplier Part Number 3: 7925925P; Watchdog Timer: Yes
